# Altium schematic sheet P02_STPM33.SchDoc
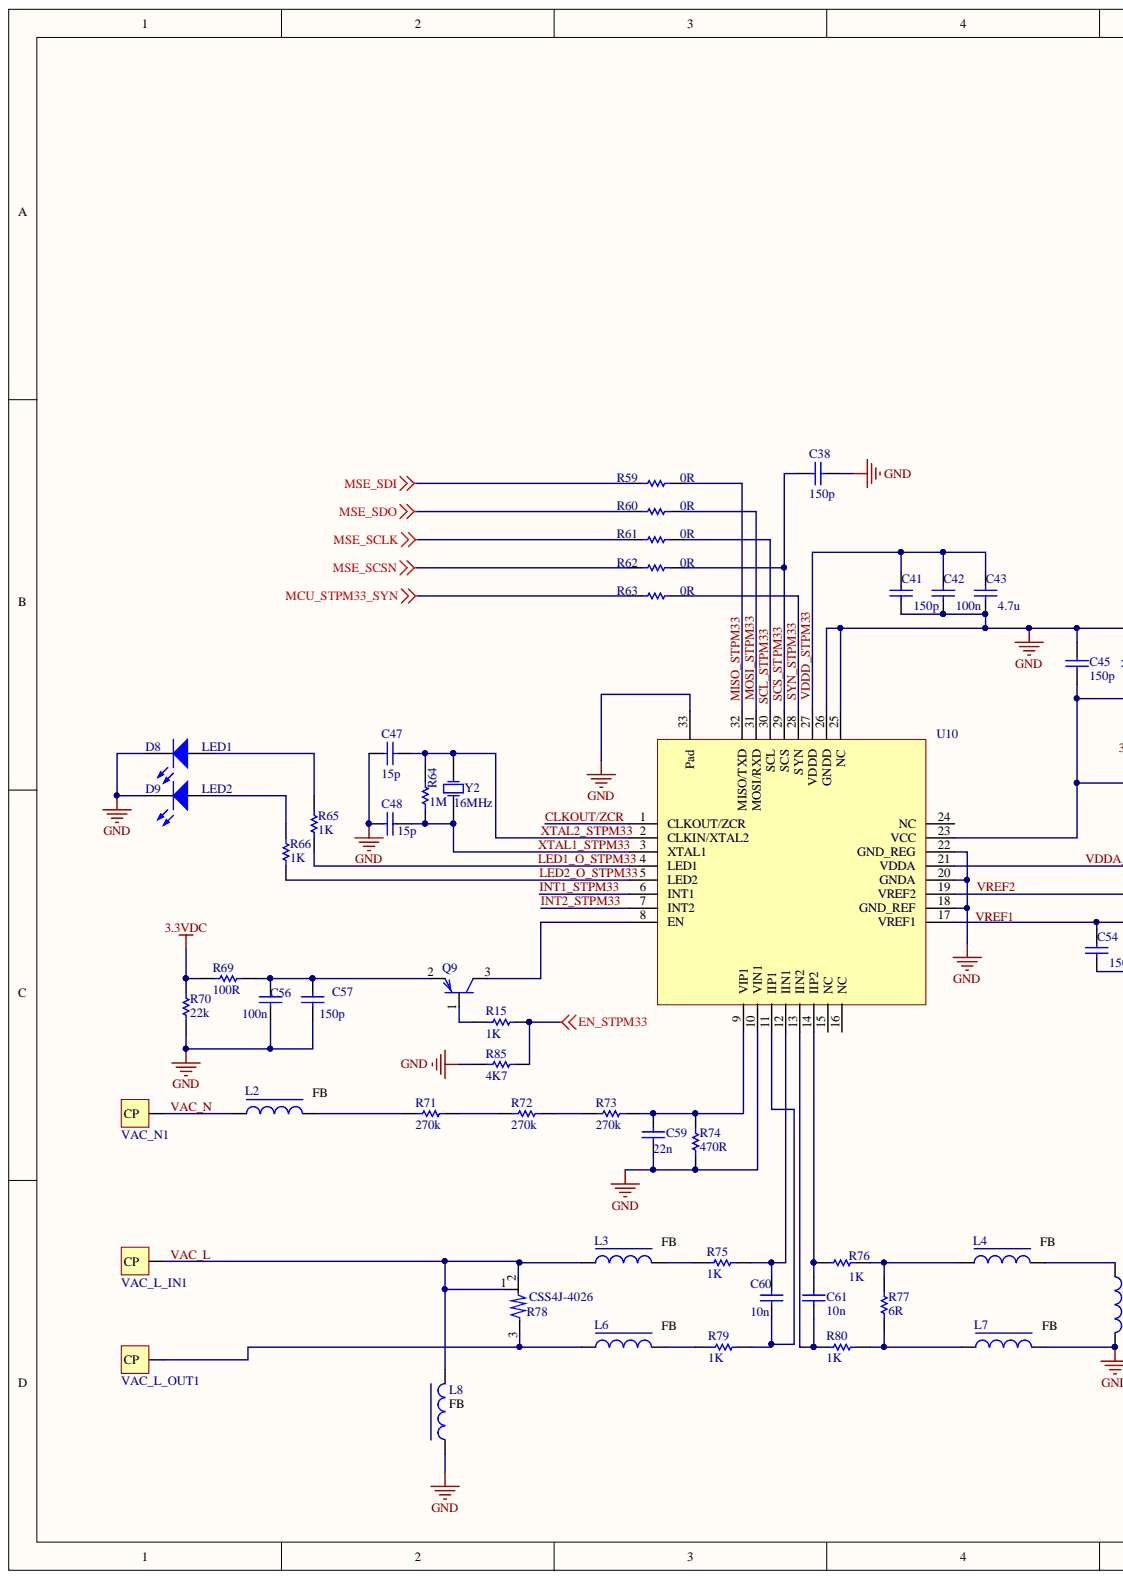
[diagram p0: P02_STPM33.SchDoc, left]
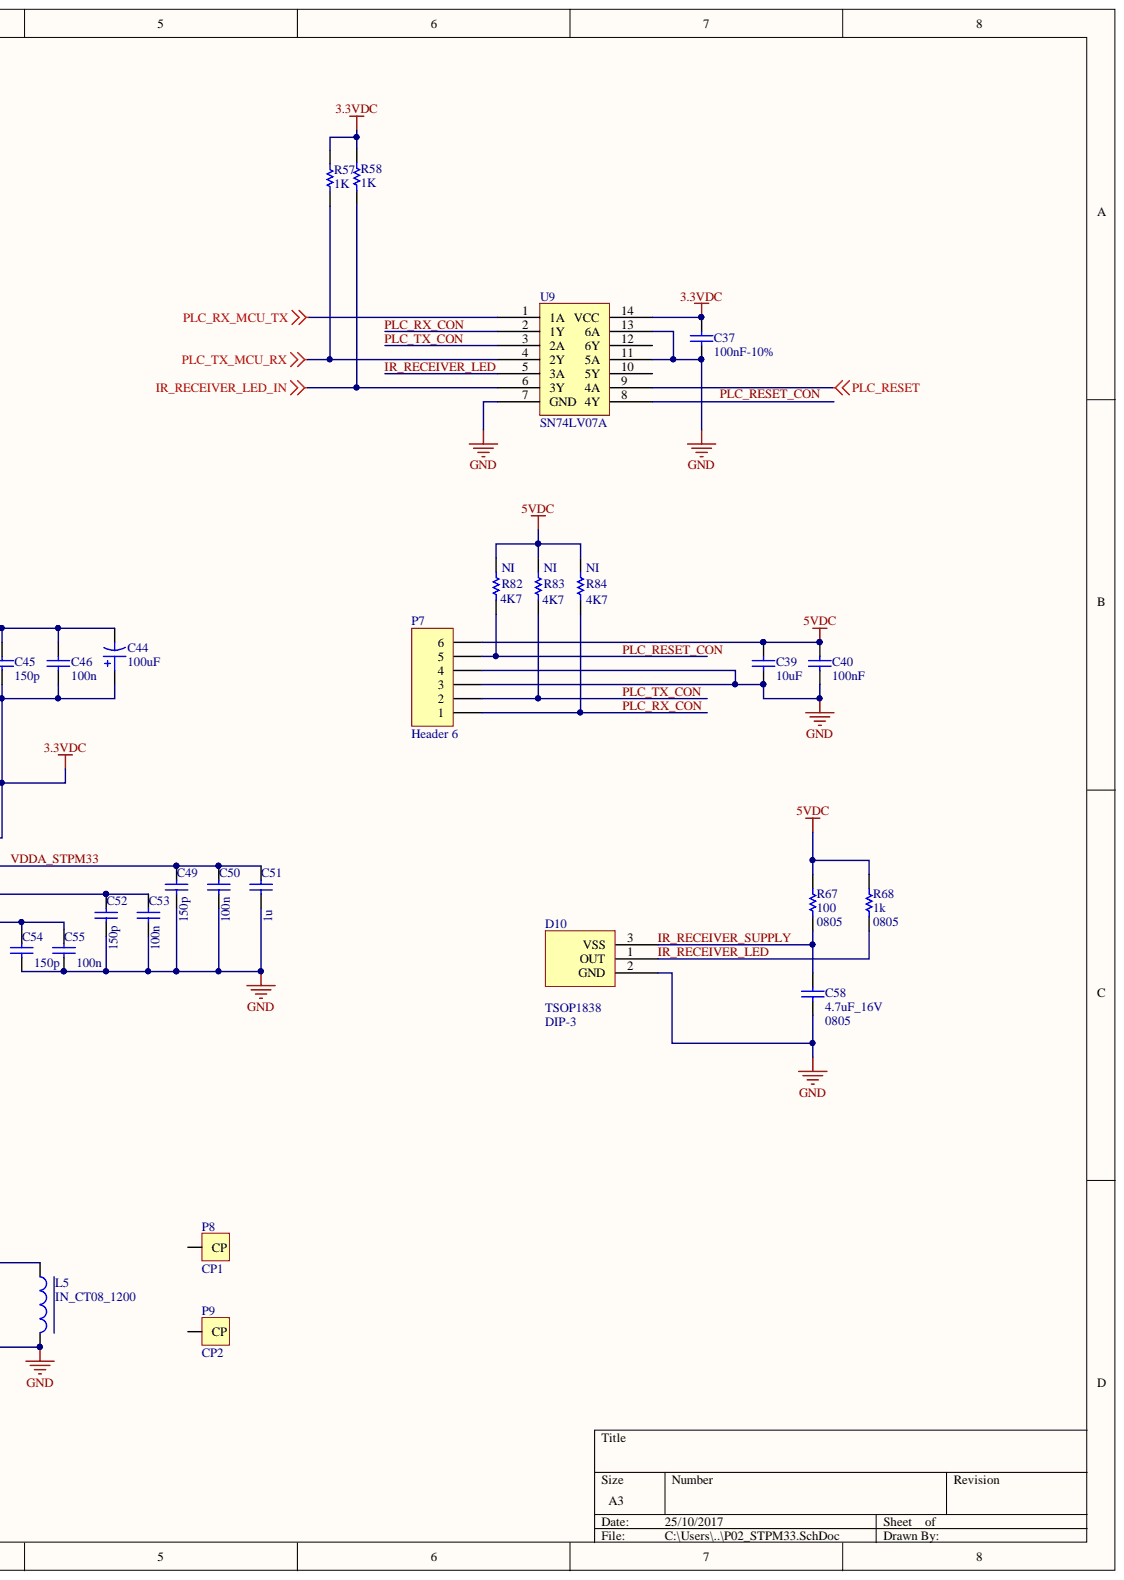
[diagram p1: P02_STPM33.SchDoc, right]

## Parts
C37  100nF-10%  [C-NP-0805]
C38  150p  [C-NP-0805]
C39  10uF  [C-NP-0805]
C40  100nF  [C-NP-0805]
C41  150p  [C-NP-0805]
C42  100n  [C-NP-0805]
C43  4.7u  [C-NP-0805]
C44  100uF  [RADIAL C]
C45  150p  [C-NP-0805]
C46  100n  [C-NP-0805]
C47  15p  [C-NP-0805]
C48  15p  [C-NP-0805]
C49  150p  [C-NP-0805]
C50  100n  [C-NP-0805]
C51  1u  [C-NP-0805]
C52  150p  [C-NP-0805]
C53  100n  [C-NP-0805]
C54  150p  [C-NP-0805]
C55  100n  [C-NP-0805]
C56  100n  [C-NP-0805]
C57  150p  [C-NP-0805]
C58  4.7uF_16V  PCB_Footprint: 0805  [C-NP-0805]
C59  22n  [C-NP-0805]
C60  10n  [C-NP-0805]
C61  10n  [C-NP-0805]
D8  LED1  [LED-0805 BLUE]
D9  LED2  [LED-0805 BLUE]
D10  TSOP1838  PCB_Footprint: DIP-3  [TSOP1838]
L2  FB  [DO-41]
L3  FB  [DO-41]
L4  FB  [DO-41]
L5  IN_CT08_1200  [Current_sense_CT08-1200]
L6  FB  [DO-41]
L7  FB  [DO-41]
L8  FB  [DO-41]
P7  Header 6  [Header 6]
P8  CP1  [CP]
P9  CP2  [CP]
Q9  A1015  [SOT-23]  (value not drawn)
R15  value 1K  [R-0805]  (hidden comment: Resistor)
R57  1K  [R-0805]
R58  1K  [R-0805]
R59  0R  [R-0805]
R60  0R  [R-0805]
R61  0R  [R-0805]
R62  0R  [R-0805]
R63  0R  [R-0805]
R64  1M  [R-0805]
R65  1K  [R-0805]
R66  1K  [R-0805]
R67  100  PCB_Footprint: 0805  [R-0805]
R68  1k  PCB_Footprint: 0805  [R-0805]
R69  100R  [R-0805]
R70  22k  [R-0805]
R71  270k  [R-0805]
R72  270k  [R-0805]
R73  270k  [R-0805]
R74  470R  [R-0805]
R75  1K  [R-0805]
R76  1K  [R-0805]
R77  6R  [R-0805]
R78  CSS4J-4026  [Shunt_CSS4J-4026]
R79  1K  [R-0805]
R80  1K  [R-0805]
R82  4K7  Publisher: NI  [R-0805]
R83  4K7  Publisher: NI  [R-0805]
R84  4K7  Publisher: NI  [R-0805]
R85  4K7  [R-0805]
U9  SN74LV07A  [SOIC14]
U10  STPM33  [QFN32L(5x5x1)]
VAC_L_IN1  Connect Pad  [CP]  (value not drawn)
VAC_L_OUT1  Connect Pad  [CP]  (value not drawn)
VAC_N1  Connect Pad  [CP]  (value not drawn)
Y2  16MHz  [CRYSTAL_SMD]

## Nets
3.3VDC: C37.2 C44.1 C45.1 C46.1 R57.2 R58.2 R69.1 R70.2 U9.14(VCC) U10.23(VCC)
5VDC: C39.2 C40.2 P7.6 R67.1 R68.1 R82.2 R83.2 R84.2
EN_STPM33: R15.2 R85.2
GND: C37.1 C38.1 C39.1 C40.1 C41.1 C42.1 C43.1 C44.2 C45.2 C46.2 C47.1 C48.1 C49.1 C50.1 C51.1 C52.1 C53.1 C54.1 C55.1 C56.1 C57.1 C58.2 C59.1 D8.2 D9.2 D10.2 L5.2 L7.2 L8.1 P7.3 P7.4 R70.1 R74.1 R85.1 U9.7(GND) U9.11(5A) U9.13(6A) U10.10(VIN1) U10.18(GND_REF) U10.20(GNDA) U10.22(GND_REG) U10.25(NC) U10.26(GNDD) U10.33(Pad)
IR_RECEIVER_LED_IN: R58.1 U9.6(3Y)
MCU_STPM33_SYN: R63.2
MSE_SCLK: R61.2
MSE_SCSN: R62.2
MSE_SDI: R59.2
MSE_SDO: R60.2
PLC_RESET: U9.9(4A)
PLC_RX_MCU_TX: U9.1(1A)
PLC_TX_MCU_RX: R57.1 U9.4(2Y)
CLKOUT/ZCR: U10.1(CLKOUT/ZCR)
INT1_STPM33: U10.6(INT1)
INT2_STPM33: U10.7(INT2)
IR_RECEIVER_LED: D10.1 R68.2 U9.5(3A)
IR_RECEIVER_SUPPLY: C58.1 D10.3 R67.2
LED1_O_STPM33: R65.1 U10.4(LED1)
LED2_O_STPM33: R66.1 U10.5(LED2)
MISO_STPM33: R59.1 U10.32(MISO/TXD)
MOSI_STPM33: R60.1 U10.31(MOSI/RXD)
PLC_RESET_CON: P7.5 R82.1
PLC_RX_CON: P7.1 R84.1 U9.2(1Y)
PLC_TX_CON: P7.2 R83.1 U9.3(2A)
SCL_STPM33: R61.1 U10.30(SCL)
SCS_STPM33: C38.2 R62.1 U10.29(SCS)
SYN_STPM33: R63.1 U10.28(SYN)
VAC_L: L3.2 L8.2 R78.1 R78.2 VAC_L_IN1.1
VAC_N: L2.1 VAC_N1.1
VDDA_STPM33: C49.2 C50.2 C51.2 U10.21(VDDA)
VDDD_STPM33: C41.2 C42.2 C43.2 U10.27(VDDD)
VREF2: C52.2 C53.2 U10.19(VREF2)
XTAL1_STPM33: C48.2 R64.1 U10.3(XTAL1) Y2.1
XTAL2_STPM33: C47.2 R64.2 U10.2(CLKIN/XTAL2) Y2.2
NetC54_2: C54.2 C55.2 U10.17(VREF1)
NetC56_2: C56.2 C57.2 Q9.2 R69.2
NetC59_2: C59.2 R73.2 R74.2 U10.9(VIP1)
NetC60_1: C60.1 R75.2 U10.12(IIN1)
NetC60_2: C60.2 R79.2 U10.11(IIP1)
NetC61_1: C61.1 R76.1 U10.14(IIP2)
NetC61_2: C61.2 R80.1 U10.13(IIN2)
NetD8_1: D8.1 R65.2
NetD9_1: D9.1 R66.2
NetL2_2: L2.2 R71.2
NetL3_1: L3.1 R75.1
NetL4_1: L4.1 R76.2 R77.2
NetL4_2: L4.2 L5.1
NetL6_1: L6.1 R79.1
NetL6_2: L6.2 R78.3 VAC_L_OUT1.1
NetL7_1: L7.1 R77.1 R80.2
NetQ9_1: Q9.1 R15.1
NetQ9_3: Q9.3 U10.8(EN)
NetR71_1: R71.1 R72.2
NetR72_1: R72.1 R73.1
VREF1:

Not connected: P8 1; P9 1; U9 8(4Y) 10(5Y) 12(6Y); U10 15(NC) 16(NC) 24(NC)

## Library data of the parts
C37 C40 = 100nF-50V-C0805: Non-Polarized Capacitor; Manufacturer: Yageo; Manufacturer Part Number: CC0805KRX7R9BB104; Component Kind: Standard; Digi-Key Part Number: 311-1140-1-ND; Library Name: Capacitor.SchLib; Unit Price: 0.1
  C37: Value: 100nF-10%
  C40: Value: 100nF
C38 C41-C43 C45-C61 = Cap Non-pol: Non-polarized Capacitor; Component Kind: Standard; Library Name: hphonglib.SchLib
  C38 C41 C45 C49 C52 C54 C57: LatestRevisionDate: 17-Jul-2002; LatestRevisionNote: Re-released for DXP Platform.; PackageReference: RAD-0.3; Published: 8-Jun-2000; Value: 150p
  C42 C46 C50 C53 C55 C56: LatestRevisionDate: 17-Jul-2002; LatestRevisionNote: Re-released for DXP Platform.; PackageReference: RAD-0.3; Published: 8-Jun-2000; Value: 100n
  C43: LatestRevisionDate: 17-Jul-2002; LatestRevisionNote: Re-released for DXP Platform.; PackageReference: RAD-0.3; Published: 8-Jun-2000; Value: 4.7u
  C47 C48: LatestRevisionDate: 17-Jul-2002; LatestRevisionNote: Re-released for DXP Platform.; PackageReference: RAD-0.3; Published: 8-Jun-2000; Value: 15p
  C51: LatestRevisionDate: 17-Jul-2002; LatestRevisionNote: Re-released for DXP Platform.; PackageReference: RAD-0.3; Published: 8-Jun-2000; Value: 1u
  C58: Part: 4.7uF_16V
  C59: LatestRevisionDate: 17-Jul-2002; LatestRevisionNote: Re-released for DXP Platform.; PackageReference: RAD-0.3; Published: 8-Jun-2000; Value: 22n
  C60 C61: LatestRevisionDate: 17-Jul-2002; LatestRevisionNote: Re-released for DXP Platform.; PackageReference: RAD-0.3; Published: 8-Jun-2000; Value: 10n
  C38 C41-C43 C45-C57 C59-C61: Publisher: Altium Limited
C39 = 100nF-50V-C0805: Non-Polarized Capacitor; Manufacturer: Yageo; Manufacturer Part Number: CC0805KRX7R9BB104; Value: 1uF; Component Kind: Standard; Digi-Key Part Number: 311-1140-1-ND; Library Name: Capacitor.SchLib; Unit Price: 0.1
C44 = Cap Pol: Polarized Capacitor; Component Kind: Standard; LatestRevisionDate: 17-Jul-2002; LatestRevisionNote: Re-released for DXP Platform.; PackageReference: POLAR0.8; Published: 8-Jun-2000; Value: 100uF; Library Name: hphonglib.SchLib; Publisher: Altium Limited
D8 D9 = LED: Typical LED; Component Kind: Standard; LatestRevisionDate: 08-Jul-2005; LatestRevisionNote: Mech Layer 1 removed.; PackageReference: LED-1; Published: 8-Jun-2000; Library Name: hphonglib.SchLib; Publisher: Altium Limited
L2-L4 L6-L8 = Inductor Ferrite: Cuon Cam L; Code_IEC: 1005; Vendor: Digikey; Code_JEITA: 0402; Component Kind: Standard; ComponentLink1Description: Digikey Part Link; ComponentLink2Description: Open Datasheet; LatestRevisionDate: 17-Jul-2002; LatestRevisionNote: Re-released for DXP Platform.; PackageReference: 0402-A; Published: 8-Jun-2000; Signal Integrity: Inductor; Value: FB; ComponentLink2URL: H:\LIBRARY\LIBRARY.Datasheet\; Library Name: hphonglib.SchLib; Publisher: Altium Limited
L5 = Inductor Ferrite: Cuon Cam L; Code_IEC: 1005; Value: Bien Dong; Vendor: Digikey; Code_JEITA: 0402; Component Kind: Standard; ComponentLink1Description: Digikey Part Link; ComponentLink2Description: Open Datasheet; LatestRevisionDate: 17-Jul-2002; LatestRevisionNote: Re-released for DXP Platform.; PackageReference: 0402-A; Published: 8-Jun-2000; Signal Integrity: Inductor; ComponentLink2URL: H:\LIBRARY\LIBRARY.Datasheet\; Library Name: hphonglib.SchLib; Publisher: Altium Limited
P7 = Header 6: Header, 6-Pin; Component Kind: Standard; LatestRevisionDate: 17-Jul-2002; LatestRevisionNote: Re-released for DXP Platform.; Library Name: hphonglib.SchLib; Publisher: Altium Limited
P8 P9 VAC_L_IN1 VAC_L_OUT1 VAC_N1 = Connect Pad: Connect Pad, 1-Pin; Component Kind: Standard; LatestRevisionDate: 17-Jul-2002; LatestRevisionNote: Re-released for DXP Platform.; Publisher: Altium Limited
  P8 P9: Library Name: hphonglib.SchLib
  VAC_L_IN1 VAC_L_OUT1 VAC_N1: Library Name: phong.SchLib
Q9 = A1015: Q2SA1015; Ic: 150mA; Pc: 200mW; PSpiceTemplate: Q^@REFDES %c %b %e @MODEL; VCBO: -50V; VEBO: -5V; Vendor: Digikey; COMPONENT: 2SA1015; Component Kind: Standard; ComponentLink1Description: Digikey Part Link; ComponentLink2Description: Open Datasheet; Price: 500VND; Published: 13-April-2009; Simulation: Q2SA1015; VCEO: -50V; ComponentLink2URL: H:\LIBRARY\LIBRARY.Datasheet\; Library Name: hphonglib.SchLib; Publisher: Hoang Nguyen
R57-R77 R79 R80 R82-R85 = Resistor: Component Kind: Standard; Library Name: hphonglib.SchLib
  R57 R58 R65 R66 R75 R76 R79 R80: Description: Resistor; LatestRevisionDate: 17-Jul-2002; LatestRevisionNote: Re-released for DXP Platform.; PackageReference: AXIAL-0.3; Published: 8-Jun-2000; Value: 1K
  R59-R63: Description: Resistor; LatestRevisionDate: 17-Jul-2002; LatestRevisionNote: Re-released for DXP Platform.; PackageReference: AXIAL-0.3; Published: 8-Jun-2000; Value: 0R
  R64: Description: Resistor; LatestRevisionDate: 17-Jul-2002; LatestRevisionNote: Re-released for DXP Platform.; PackageReference: AXIAL-0.3; Published: 8-Jun-2000; Value: 1M
  R67: Part: 100
  R68: Part: 1k
  R69: Description: Resistor; LatestRevisionDate: 17-Jul-2002; LatestRevisionNote: Re-released for DXP Platform.; PackageReference: AXIAL-0.3; Published: 8-Jun-2000; Value: 100R
  R70: Description: Resistor; LatestRevisionDate: 17-Jul-2002; LatestRevisionNote: Re-released for DXP Platform.; PackageReference: AXIAL-0.3; Published: 8-Jun-2000; Value: 22k
  R71-R73: Description: Resistor; LatestRevisionDate: 17-Jul-2002; LatestRevisionNote: Re-released for DXP Platform.; PackageReference: AXIAL-0.3; Published: 8-Jun-2000; Value: 270k
  R74: Description: Resistor; LatestRevisionDate: 17-Jul-2002; LatestRevisionNote: Re-released for DXP Platform.; PackageReference: AXIAL-0.3; Published: 8-Jun-2000; Value: 470R
  R77: Description: Resistor; LatestRevisionDate: 17-Jul-2002; LatestRevisionNote: Re-released for DXP Platform.; PackageReference: AXIAL-0.3; Published: 8-Jun-2000; Value: 6R
  R82-R85: Description: Resistor; LatestRevisionDate: 17-Jul-2002; LatestRevisionNote: Re-released for DXP Platform.; PackageReference: AXIAL-0.3; Published: 8-Jun-2000; Value: 4K7
  R57-R66 R69-R77 R79 R80 R85: Publisher: Altium Limited
R78 = R Shunt 3d: Component Kind: Standard; Library Name: HuySch.SchLib
U9 = 74HC04P1: Component Kind: Standard; Library Name: 74HC04P1.SchLib
Y2 = XTAL: Crystal Oscillator; Component Kind: Standard; LatestRevisionDate: 17-Jul-2002; LatestRevisionNote: Re-released for DXP Platform.; PackageReference: R38; Published: 8-Jun-2000; Library Name: hphonglib.SchLib; Publisher: Altium Limited
D10 = TSOP1838: Component Kind: Standard; Library Name: TSOP1838.SchLib; Part: TSOP1838
R15 = Resistor: Component Kind: Standard; Description: Resistor; LatestRevisionDate: 17-Jul-2002; LatestRevisionNote: Re-released for DXP Platform.; PackageReference: AXIAL-0.3; Published: 8-Jun-2000; Library Name: hphonglib.SchLib; Publisher: Altium Limited
U10 = STPM33: Component Kind: Standard; Library Name: HuySch.SchLib
